# Altium schematic sheet P02_Photon.SchDoc
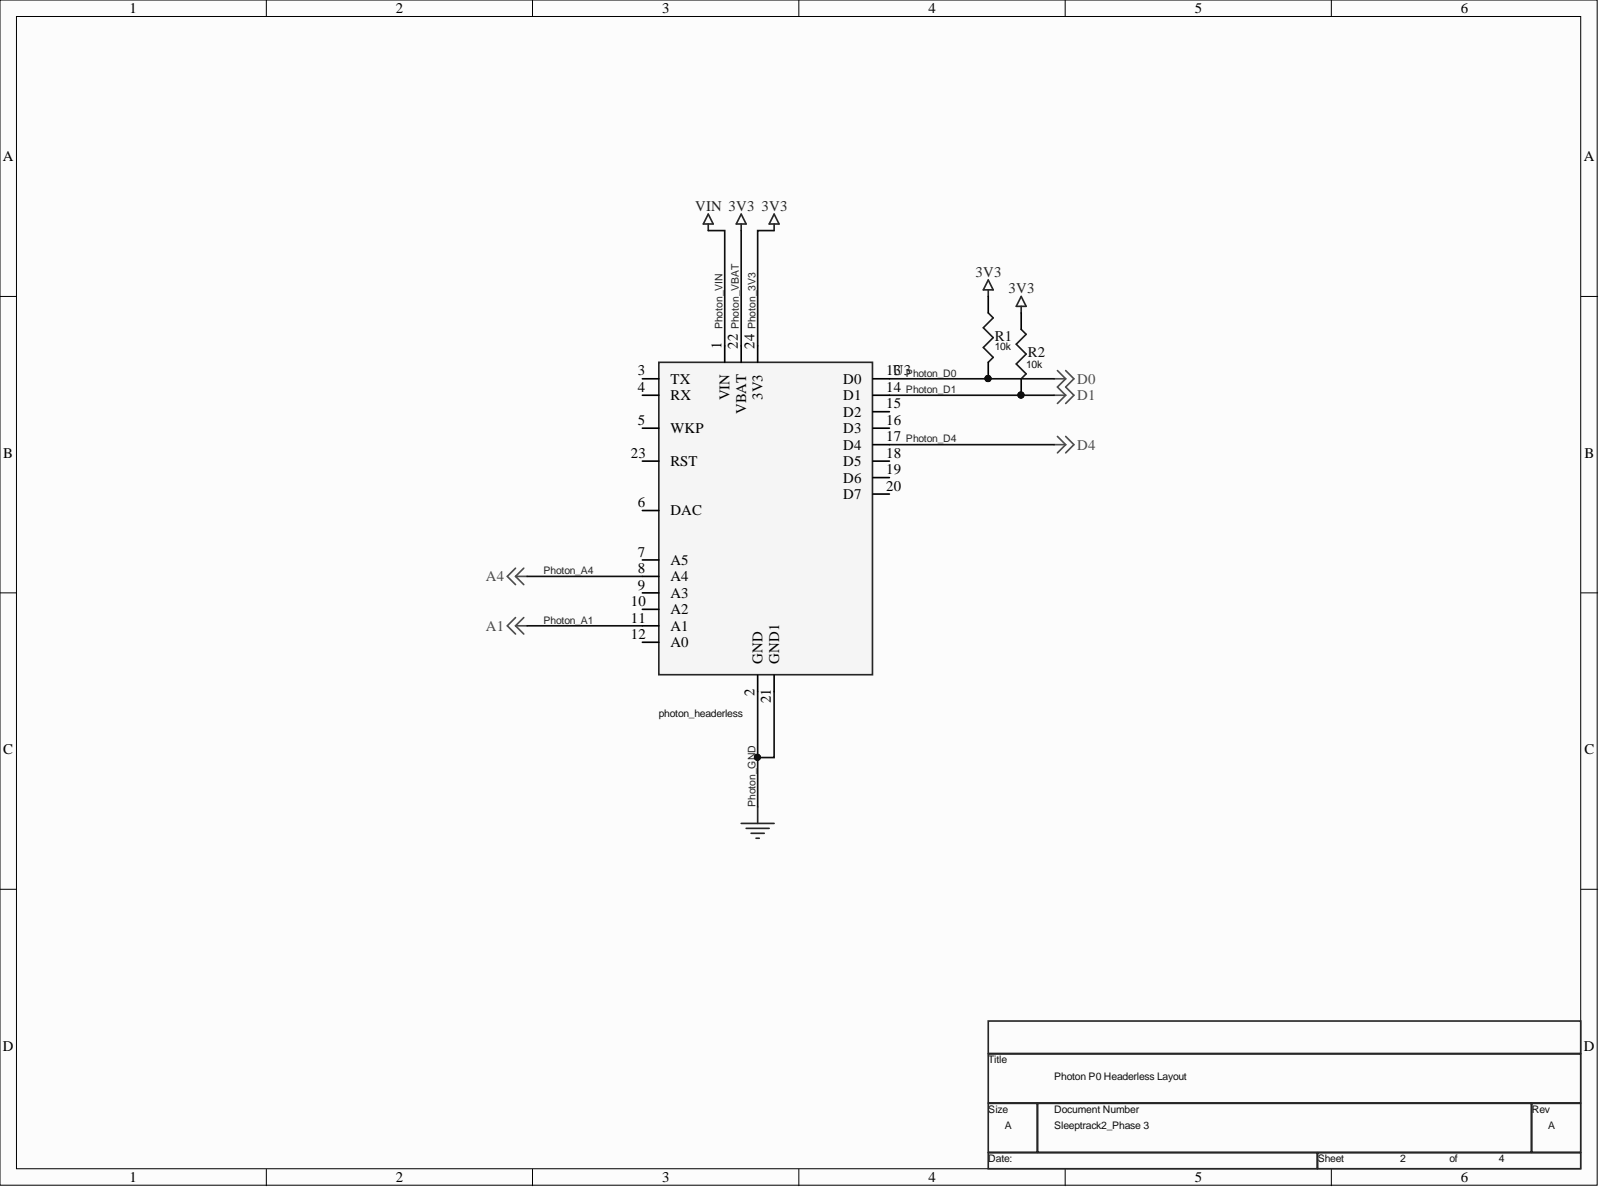
[diagram p0: P02_Photon.SchDoc]

## Parts
R1  10k  [RESC402N]
R2  10k  [RESC402N]
U3  photon_headerless  [Photon P0 - duplicate]

## Nets
PHOTON_3V3: R1.1 R2.1 U3.22(VBAT) U3.24(3V3)
PHOTON_A1: U3.11(A1)
PHOTON_A4: U3.8(A4)
PHOTON_D0: R1.2 U3.13(D0)
PHOTON_D1: R2.2 U3.14(D1)
PHOTON_D4: U3.17(D4)
PHOTON_GND: U3.2(GND) U3.21(GND1)
PHOTON_VIN: U3.1(VIN)

Not connected: U3 3(TX) 4(RX) 5(WKP) 6(DAC) 7(A5) 9(A3) 10(A2) 12(A0) 15(D2) 16(D3) 18(D5) 19(D6) 20(D7) 23(RST)

## Library data of the parts
R1 R2 = RC0402FR-0710KL: RES SMD 10K OHM 1% 1/16W 0402; Component Kind: Standard; Library Name: SLEEPTRACK2_PHASE3_Library.SchLib
U3 = photon_headerless: Component Kind: Standard; Library Name: SLEEPTRACK2_PHASE3_Library.SchLib
